# Altium schematic sheet 24V Multiphase Buck.SchDoc
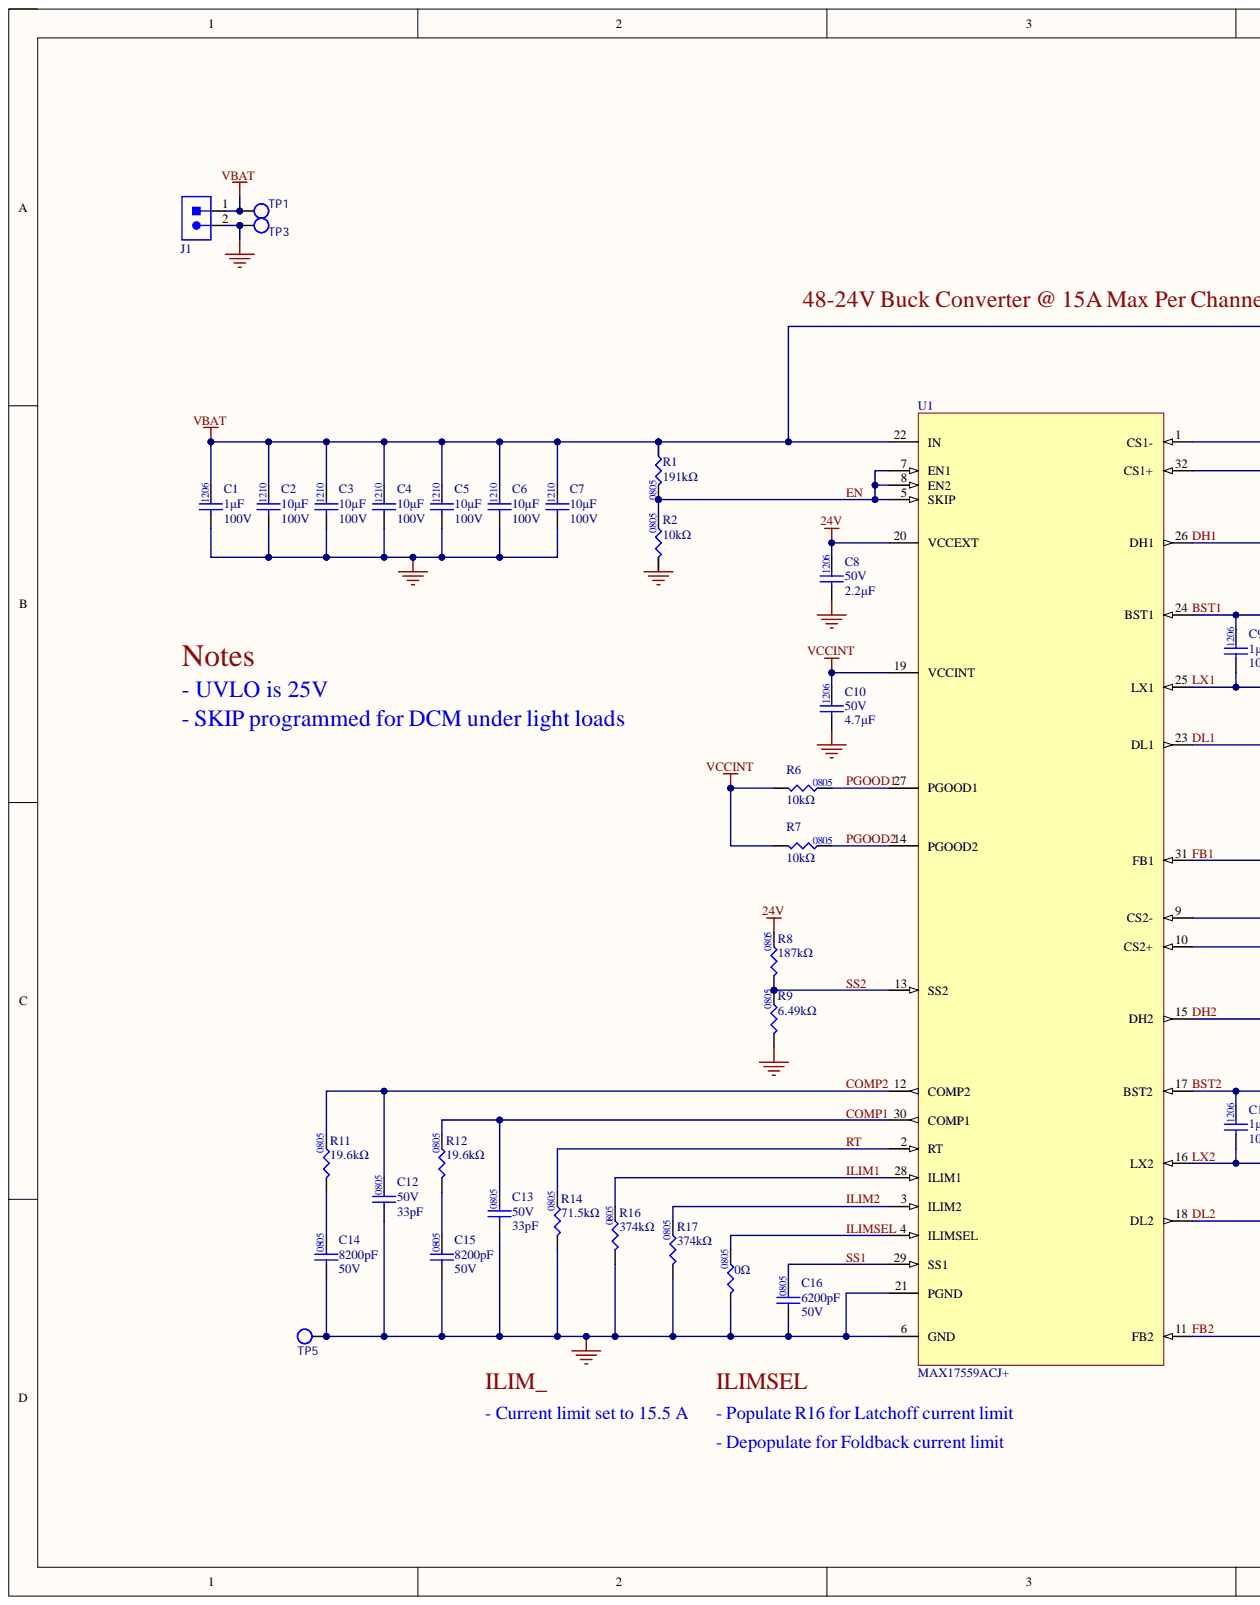
[diagram p0: 24V Multiphase Buck.SchDoc, left]
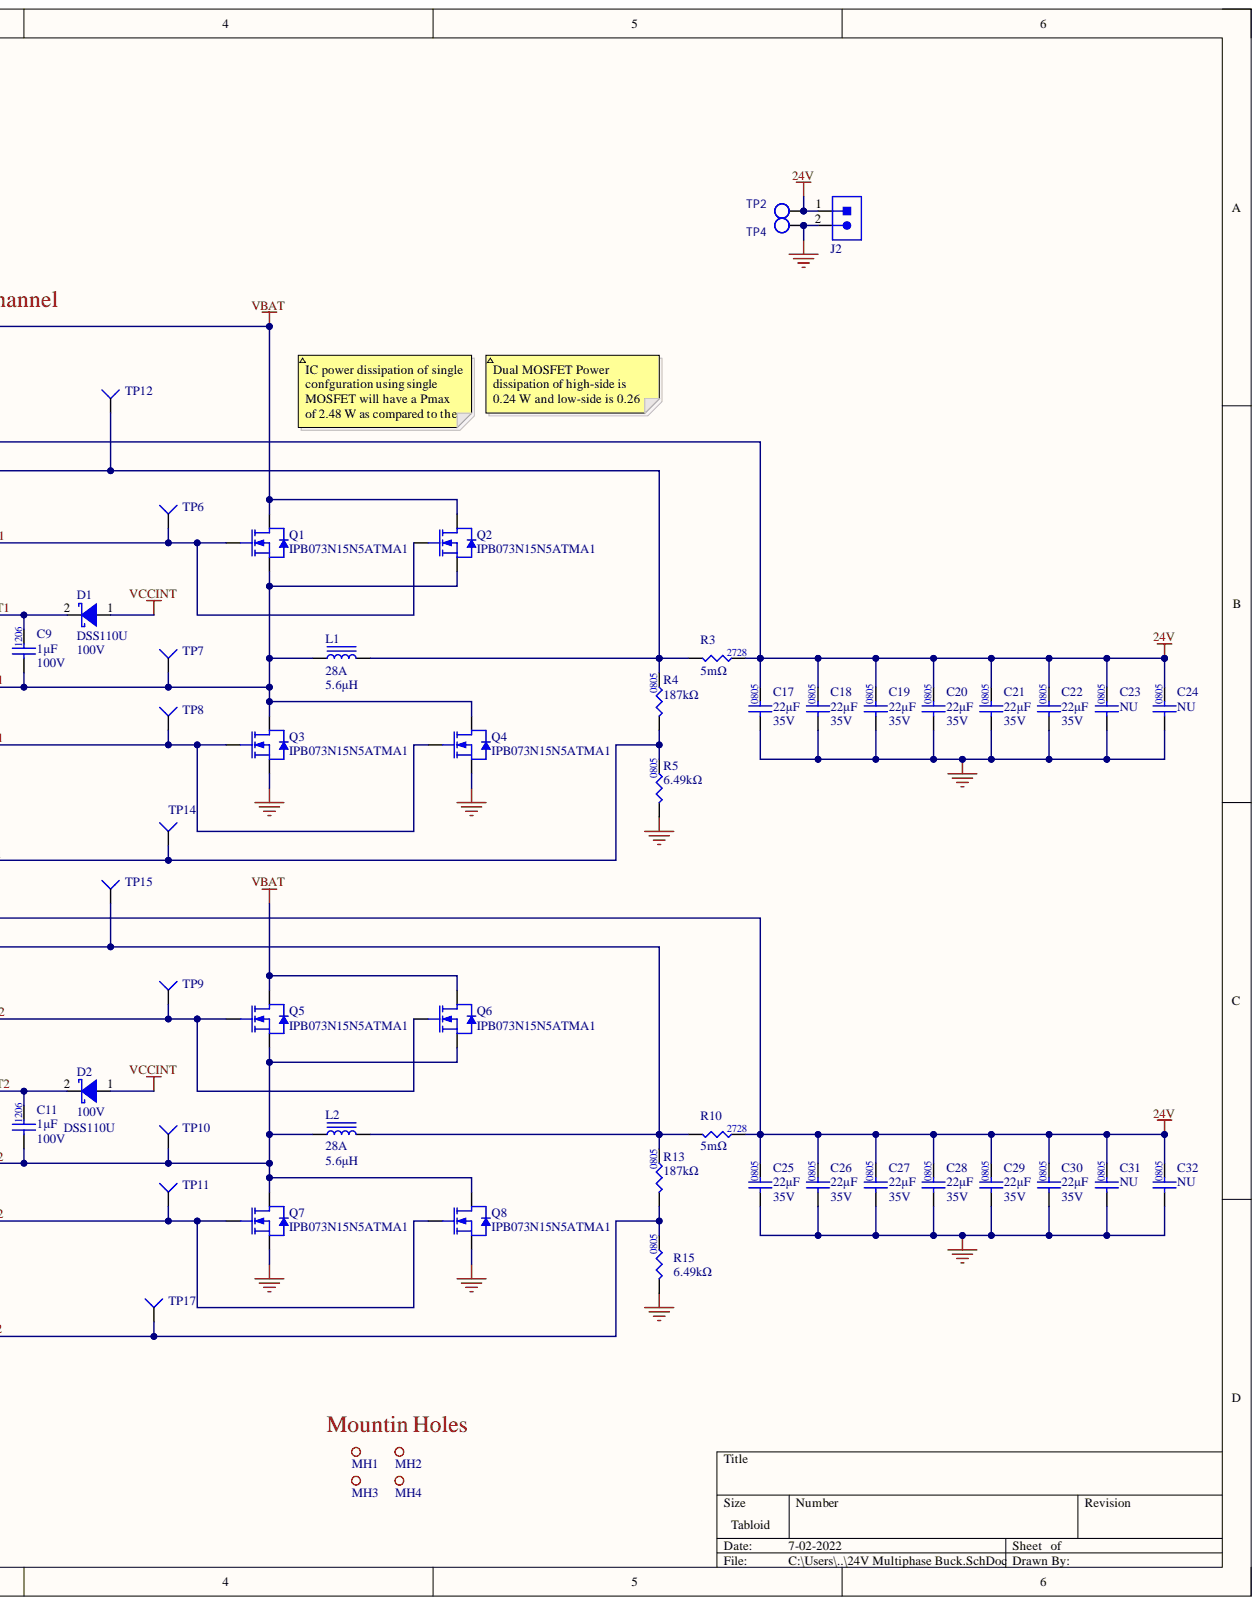
[diagram p1: 24V Multiphase Buck.SchDoc, right]

## Parts
C1  value 1μF  Package: 1206  Voltage Rating: 100V  [CAPC3216X190X50NL20T25]  (hidden comment: CAP_1uF_100V_1206)
C2  value 10μF  Package: 1210  Voltage Rating: 100V  [CAP1210]  (hidden comment: CAP_10uF_100V_1210)
C3  value 10μF  Package: 1210  Voltage Rating: 100V  [CAP1210]  (hidden comment: CAP_10uF_100V_1210)
C4  value 10μF  Package: 1210  Voltage Rating: 100V  [CAP1210]  (hidden comment: CAP_10uF_100V_1210)
C5  value 10μF  Package: 1210  Voltage Rating: 100V  [CAP1210]  (hidden comment: CAP_10uF_100V_1210)
C6  value 10μF  Package: 1210  Voltage Rating: 100V  [CAP1210]  (hidden comment: CAP_10uF_100V_1210)
C7  value 10μF  Package: 1210  Voltage Rating: 100V  [CAP1210]  (hidden comment: CAP_10uF_100V_1210)
C8  value 2.2μF  Voltage Rating: 50V  [CAP1206]  (hidden comment: CAP_2.2uF_50V_1206)
C9  value 1μF  Package: 1206  Voltage Rating: 100V  [CAPC3216X190X50NL20T25]  (hidden comment: CAP_1uF_100V_1206)
C10  value 4.7μF  Package: 1206  Voltage Rating: 50V  [CAP1206]  (hidden comment: CAP_4.7uF_50V_1206)
C11  value 1μF  Package: 1206  Voltage Rating: 100V  [CAPC3216X190X50NL20T25]  (hidden comment: CAP_1uF_100V_1206)
C12  value 33pF  Package: 0805  Voltage Rating: 50V  [CAP0805]  (hidden comment: CAP_33pF_50V_0805)
C13  value 33pF  Package: 0805  Voltage Rating: 50V  [CAP0805]  (hidden comment: CAP_33pF_50V_0805)
C14  Capacitance: 8200pF  Package: 0805  Voltage Rating: 50V  [CAP0805]  (hidden comment: CAP_8200pF_50V_0805)
C15  Capacitance: 8200pF  Package: 0805  Voltage Rating: 50V  [CAP0805]  (hidden comment: CAP_8200pF_50V_0805)
C16  Capacitance: 6200pF  Package/Footprint: 0805  Voltage Rating: 50V  [CAP0805]  (hidden comment: CAP_6200pF_50V_0805)
C17  value 22μF  Voltage Rating: 35V  [CAP1206]  (hidden comment: CAP_22uF_35V_1206)
C18  value 22μF  Voltage Rating: 35V  [CAP1206]  (hidden comment: CAP_22uF_35V_1206)
C19  value 22μF  Voltage Rating: 35V  [CAP1206]  (hidden comment: CAP_22uF_35V_1206)
C20  value 22μF  Voltage Rating: 35V  [CAP1206]  (hidden comment: CAP_22uF_35V_1206)
C21  value 22μF  Voltage Rating: 35V  [CAP1206]  (hidden comment: CAP_22uF_35V_1206)
C22  value 22μF  Voltage Rating: 35V  [CAP1206]  (hidden comment: CAP_22uF_35V_1206)
C23  value NU  [CAP1206]  (hidden comment: CAP_NU_1206)
C24  value NU  [CAP1206]  (hidden comment: CAP_NU_1206)
C25  value 22μF  Voltage Rating: 35V  [CAP1206]  (hidden comment: CAP_22uF_35V_1206)
C26  value 22μF  Voltage Rating: 35V  [CAP1206]  (hidden comment: CAP_22uF_35V_1206)
C27  value 22μF  Voltage Rating: 35V  [CAP1206]  (hidden comment: CAP_22uF_35V_1206)
C28  value 22μF  Voltage Rating: 35V  [CAP1206]  (hidden comment: CAP_22uF_35V_1206)
C29  value 22μF  Voltage Rating: 35V  [CAP1206]  (hidden comment: CAP_22uF_35V_1206)
C30  value 22μF  Voltage Rating: 35V  [CAP1206]  (hidden comment: CAP_22uF_35V_1206)
C31  value NU  [CAP1206]  (hidden comment: CAP_NU_1206)
C32  value NU  [CAP1206]  (hidden comment: CAP_NU_1206)
D1  Manufacturer Part Number 1: DSS110U  Vr (Max): 100V  [SOD-123FL]  (hidden comment: DIO_SCHOTTKY_100V_1A)
D2  Manufacturer Part Number 1: DSS110U  Vr (Max): 100V  [SOD-123FL]  (hidden comment: DIO_SCHOTTKY_100V_1A)
J1  CON_2POS_SCREW_TERM  [CON_2POS_SCREW_TERM_65A]  (value not drawn)
J2  CON_2POS_SCREW_TERM  [CON_2POS_SCREW_TERM_65A]  (value not drawn)
L1  Current Rating: 28A  Inductance: 5.6μH  [IND_VISHAY_IHLP6767GZ11]  (hidden comment: IND_5.6uH_28A)
L2  Current Rating: 28A  Inductance: 5.6μH  [IND_VISHAY_IHLP6767GZ11]  (hidden comment: IND_5.6uH_28A)
MH1  MOUNTING_HOLE_M3  [MOUNTING_HOLE_3mm]  (value not drawn)  (no BOM)
MH2  MOUNTING_HOLE_M3  [MOUNTING_HOLE_3mm]  (value not drawn)  (no BOM)
MH3  MOUNTING_HOLE_M3  [MOUNTING_HOLE_3mm]  (value not drawn)  (no BOM)
MH4  MOUNTING_HOLE_M3  [MOUNTING_HOLE_3mm]  (value not drawn)  (no BOM)
Q1  Manufacturer Part Number 1: IPB073N15N5ATMA1  [NMOS_DPAK263-3-2]  (hidden comment: NMOS_150V_114A)
Q2  Manufacturer Part Number 1: IPB073N15N5ATMA1  [NMOS_DPAK263-3-2]  (hidden comment: NMOS_150V_114A)
Q3  Manufacturer Part Number 1: IPB073N15N5ATMA1  [NMOS_DPAK263-3-2]  (hidden comment: NMOS_150V_114A)
Q4  Manufacturer Part Number 1: IPB073N15N5ATMA1  [NMOS_DPAK263-3-2]  (hidden comment: NMOS_150V_114A)
Q5  Manufacturer Part Number 1: IPB073N15N5ATMA1  [NMOS_DPAK263-3-2]  (hidden comment: NMOS_150V_114A)
Q6  Manufacturer Part Number 1: IPB073N15N5ATMA1  [NMOS_DPAK263-3-2]  (hidden comment: NMOS_150V_114A)
Q7  Manufacturer Part Number 1: IPB073N15N5ATMA1  [NMOS_DPAK263-3-2]  (hidden comment: NMOS_150V_114A)
Q8  Manufacturer Part Number 1: IPB073N15N5ATMA1  [NMOS_DPAK263-3-2]  (hidden comment: NMOS_150V_114A)
R1  value 191kΩ  Package/Footprint: 0805  [RES0805]  (hidden comment: RES_191kΩ_0805_1%)
R2  value 10kΩ  Package/Footprint: 0805  [RES0805]  (hidden comment: RES_10kΩ_0805_5%)
R3  value 5mΩ  Package/Footprint: 2728  [RES2728]  (hidden comment: RES_5mΩ_2728_1%)
R4  value 187kΩ  Package/Footprint: 0805  [RES0805]  (hidden comment: RES_187kΩ_0805_0.1%)
R5  value 6.49kΩ  Package/Footprint: 0805  [RES0805]  (hidden comment: RES_6.49kΩ_0805_1%)
R6  value 10kΩ  Package/Footprint: 0805  [RES0805]  (hidden comment: RES_10kΩ_0805_5%)
R7  value 10kΩ  Package/Footprint: 0805  [RES0805]  (hidden comment: RES_10kΩ_0805_5%)
R8  value 187kΩ  Package/Footprint: 0805  [RES0805]  (hidden comment: RES_187kΩ_0805_0.1%)
R9  value 6.49kΩ  Package/Footprint: 0805  [RES0805]  (hidden comment: RES_6.49kΩ_0805_1%)
R10  value 5mΩ  Package/Footprint: 2728  [RES2728]  (hidden comment: RES_5mΩ_2728_1%)
R11  value 19.6kΩ  Package/Footprint: 0805  [RES0805]  (hidden comment: RES_19.6kΩ_0805_1%)
R12  value 19.6kΩ  Package/Footprint: 0805  [RES0805]  (hidden comment: RES_19.6kΩ_0805_1%)
R13  value 187kΩ  Package/Footprint: 0805  [RES0805]  (hidden comment: RES_187kΩ_0805_0.1%)
R14  value 71.5kΩ  Package/Footprint: 0805  [RES0805]  (hidden comment: RES_71.5kΩ_0805_1%)
R15  value 6.49kΩ  Package/Footprint: 0805  [RES0805]  (hidden comment: RES_6.49kΩ_0805_1%)
R16  value 374kΩ  [RES1210]  (hidden comment: RES_374kΩ_1210_1%)
R17  value 374kΩ  [RES1210]  (hidden comment: RES_374kΩ_1210_1%)
R18  value 0Ω  Package/Footprint: 0805  [RES0805]  (hidden comment: RES_0Ω_0805)
TP1  TESTPOINT_LOOP  [TESTPOINT_LOOP]  (value not drawn)
TP2  TESTPOINT_LOOP  [TESTPOINT_LOOP]  (value not drawn)
TP3  TESTPOINT_LOOP  [TESTPOINT_LOOP]  (value not drawn)
TP4  TESTPOINT_LOOP  [TESTPOINT_LOOP]  (value not drawn)
TP5  TESTPOINT_LOOP  [TESTPOINT_LOOP]  (value not drawn)
TP6  TESTPOINT_1MM_CIRCLE  [TESTPOINT_CIRCLE_1MM]  (value not drawn)  (no BOM)
TP7  TESTPOINT_1MM_CIRCLE  [TESTPOINT_CIRCLE_1MM]  (value not drawn)  (no BOM)
TP8  TESTPOINT_1MM_CIRCLE  [TESTPOINT_CIRCLE_1MM]  (value not drawn)  (no BOM)
TP9  TESTPOINT_1MM_CIRCLE  [TESTPOINT_CIRCLE_1MM]  (value not drawn)  (no BOM)
TP10  TESTPOINT_1MM_CIRCLE  [TESTPOINT_CIRCLE_1MM]  (value not drawn)  (no BOM)
TP11  TESTPOINT_1MM_CIRCLE  [TESTPOINT_CIRCLE_1MM]  (value not drawn)  (no BOM)
TP12  TESTPOINT_1MM_CIRCLE  [TESTPOINT_CIRCLE_1MM]  (value not drawn)  (no BOM)
TP14  TESTPOINT_1MM_CIRCLE  [TESTPOINT_CIRCLE_1MM]  (value not drawn)  (no BOM)
TP15  TESTPOINT_1MM_CIRCLE  [TESTPOINT_CIRCLE_1MM]  (value not drawn)  (no BOM)
TP17  TESTPOINT_1MM_CIRCLE  [TESTPOINT_CIRCLE_1MM]  (value not drawn)  (no BOM)
U1  MAX17559ACJ+  [IC_32-TQFP]

## Nets
24V: C8.2 C17.2 C18.2 C19.2 C20.2 C21.2 C22.2 C23.2 C24.2 C25.2 C26.2 C27.2 C28.2 C29.2 C30.2 C31.2 C32.2 J2.1 R3.2 R8.2 R10.2 TP2.1 U1.1(CS1-) U1.9(CS2-) U1.20(VCCEXT)
GND: C1.1 C2.1 C3.1 C4.1 C5.1 C6.1 C7.1 C8.1 C10.1 C12.1 C13.1 C14.1 C15.1 C16.1 C17.1 C18.1 C19.1 C20.1 C21.1 C22.1 C23.1 C24.1 C25.1 C26.1 C27.1 C28.1 C29.1 C30.1 C31.1 C32.1 J1.2 J2.2 Q3.3 Q4.3 Q7.3 Q8.3 R5.1 R9.1 R14.1 R15.1 R16.1 R17.1 R18.1 TP3.1 TP4.1 TP5.1 U1.6(GND) U1.21(PGND)
VBAT: C1.2 C2.2 C3.2 C4.2 C5.2 C6.2 C7.2 J1.1 Q1.2 Q2.2 Q5.2 Q6.2 R1.2 TP1.1 U1.22(IN)
VCCINT: C10.2 D1.1 D2.1 R6.1 R7.1 U1.19(VCCINT)
BST1: C9.2 D1.2 U1.24(BST1)
BST2: C11.2 D2.2 U1.17(BST2)
COMP1: C13.2 R12.2 U1.30(COMP1)
COMP2: C12.2 R11.2 U1.12(COMP2)
DH1: Q1.1 Q2.1 TP6.1 U1.26(DH1)
DH2: Q5.1 Q6.1 TP9.1 U1.15(DH2)
DL1: Q3.1 Q4.1 TP8.1 U1.23(DL1)
DL2: Q7.1 Q8.1 TP11.1 U1.18(DL2)
EN: R1.1 R2.2 U1.5(SKIP) U1.7(EN1) U1.8(EN2)
FB1: R4.1 R5.2 TP14.1 U1.31(FB1)
FB2: R13.1 R15.2 TP17.1 U1.11(FB2)
ILIM1: R16.2 U1.28(ILIM1)
ILIM2: R17.2 U1.3(ILIM2)
ILIMSEL: R18.2 U1.4(ILIMSEL)
LX1: C9.1 L1.1 Q1.3 Q2.3 Q3.2 Q4.2 TP7.1 U1.25(LX1)
LX2: C11.1 L2.1 Q5.3 Q6.3 Q7.2 Q8.2 TP10.1 U1.16(LX2)
PGOOD1: R6.2 U1.27(PGOOD1)
PGOOD2: R7.2 U1.14(PGOOD2)
RT: R14.2 U1.2(RT)
SS1: C16.2 U1.29(SS1)
SS2: R8.1 R9.2 U1.13(SS2)
NetC14_2: C14.2 R11.1
NetC15_2: C15.2 R12.1
NetL1_2: L1.2 R3.1 R4.2 TP12.1 U1.32(CS1+)
NetL2_2: L2.2 R10.1 R13.2 TP15.1 U1.10(CS2+)

Not connected: R2 1

## Library data of the parts
C1 C9 C11 = CAP_1uF_100V_1206: CAP CER 1UF 100V X7R 1206; Component Kind: Standard; Mounting Type: SMD; Supplier 1: Digi-Key; Supplier Part Number 1: 490-16468-1-ND; Library Name: UWRT_Schematic_Library_2020.SchLib
C2-C7 = CAP_10uF_100V_1210: CAP CER 10uF 100V X7S 10% 1210; Manufacturer 1: Murata; Manufacturer Part Number 1: GRM32EC72A106KE05L; Component Kind: Standard; Dielectric: X7S; Mounting Type: SMD; Supplier 1: Digi-Key; Supplier Part Number 1: 490-16266-1-ND; Library Name: UWRT_Schematic_Library_2020.SchLib
C8 = CAP_2.2uF_50V_1206: CAP CER 2.2UF 50V X7R 1206; Manufacturer 1: Murata Electronics; Manufacturer Part Number 1: GCM31CR71H225KA55L; Component Kind: Standard; Dielectric: X7R; Supplier 1: Digi-Key; Supplier Part Number 1: 490-4796-1-ND; Library Name: UWRT_Schematic_Library_2020.SchLib
C10 = CAP_4.7uF_50V_1206: CAP CER 4.7UF 50V X7R 1206; Manufacturer 1: Murata; Manufacturer Part Number 1: GRM31CR71H475KA12L; Component Kind: Standard; Dielectric: X7R; Mounting Type: SMD; Supplier 1: Digi-Key; Supplier Part Number 1: 490-6521-1-ND; Library Name: UWRT_Schematic_Library_2020.SchLib
C12 C13 = CAP_33pF_50V_0805: CAP CER 33PF 50V C0G/NP0 0805; Manufacturer 1: Samsung Electro-Mechanics; Manufacturer Part Number 1: CL21C330JBANNNC; Component Kind: Standard; Dielectric: C0G/NP0; Mounting Type: SMD; Supplier 1: Digi-Key; Supplier Part Number 1: 1276-1105-1-ND; Library Name: UWRT_Schematic_Library_2020.SchLib
C14 C15 = CAP_8200pF_50V_0805: CAP CER 8200PF 50V X7R 0805; Manufacturer: YAGEO; Manufacturer Part Number: CC0805KRX7R9BB822; Component Kind: Standard; Dielectric: X7R; Mounting Type: SMD; Supplier 1: Digi-Key; Supplier Part Number 1: 311-4331-1-ND; Library Name: UWRT_Schematic_Library_2020.SchLib
C16 = CAP_6200pF_50V_0805: CAP CER 6200PF 50V C0G/NP0 0805; Manufacturer 1: Murata Electronics; Manufacturer Part Number 1: GRM2195C1H622JA01D; Component Kind: Standard; Supplier 1: Digi-Key; Supplier Part Number 1: 490-1637-1-ND; Library Name: UWRT_Schematic_Library_2020.SchLib
C17-C22 C25-C30 = CAP_22uF_35V_1206: CAP CER 22UF 35V X5R 1206; Manufacturer 1: TDK Corporation; Manufacturer Part Number 1: C3216X5R1V226M160AC; Package/Footprint: 1205; Component Kind: Standard; Dielectric: X5R; Mounting Type: Surface Mount; Supplier 1: Digi-Key; Supplier Part Number 1: 445-8045-1-ND; Library Name: UWRT_Schematic_Library_2020.SchLib
C23 C24 C31 C32 = CAP_NU_1206: PLACEHOLDER CAPACITOR; Component Kind: Standard; Mounting Type: Surface Mount; Package: 1206; Library Name: UWRT_Schematic_Library_2020.SchLib
D1 D2 = DIO_SCHOTTKY_100V_1A: DIODE SCHOTTKY 100V 1A SOD-123F; Manufacturer 1: SMC Diode Solutions; Component Kind: Standard; Mounting Type: SMD; Package/Footprint: SOD-123F; Supplier 1: Digi-Key; Supplier Part Number 1: 1655-DSS110UCT-ND; Library Name: UWRT_Schematic_Library_2020.SchLib
J1 J2 = CON_2POS_SCREW_TERM_65A: PCB TERMINAL BLOCKS RISING CLAMP; Manufacturer 1: Amphenol Anytek; Manufacturer Part Number 1: VP0265840000G; Component Kind: Standard; Mounting Type: TH; Package/Footprint: TERMINAL; Supplier 1: Digi-Key; Supplier Part Number 1: 1818-VP0265840000G-ND; Library Name: UWRT_Schematic_Library_2020.SchLib
L1 L2 = IND_5.6uH_28A: FIXED IND 5.6UH 28A 4.44MOHM SMD; Manufacturer 1: Vishay Dale; Manufacturer Part Number 1: IHLP6767GZER5R6M11; Inductance Tolerance: 20%; Saturation Current: 21A; Component Kind: Standard; DC Resistance (DCR): 4.44mOhm; Mounting Type: SMD; Supplier 1: Digi-Key; Supplier Part Number 1: 541-1283-1-ND; Library Name: UWRT_Schematic_Library_2020.SchLib
Q1-Q8 = NMOS_150V_114A: MOSFET N-CH 150V 114A TO263-3; Manufacturer 1: Infineon Technologies; Package/Footprint: TO-263-3, D²Pak; Vgs (Max): ±20V; Component Kind: Standard; Id: 114A; Mounting Type: SMD; Supplier 1: Digi-Key; Supplier Part Number 1: IPB073N15N5ATMA1CT-ND; Vdss: 150V; Library Name: UWRT_Schematic_Library_2020.SchLib
R1 = RES_191kΩ_0805_1%: RES 191K OHM 1% 1/8W 0805; Manufacturer 1: Yageo; Manufacturer Part Number 1: RC0805FR-07191KL; Component Kind: Standard; Mounting Type: SMD; Supplier 1: Digi-Key; Supplier Part Number 1: 311-191KCRTR-ND; Library Name: UWRT_Schematic_Library_2020.SchLib
R2 R6 R7 = RES_10kΩ_0805_5%: RES 10K OHM 5% 1/8W 0805; Manufacturer 1: Panasonic Electronic Components; Manufacturer Part Number 1: ERJ-6GEYJ103V; Component Kind: Standard; LCSC Part #: C17414; Mounting Type: SMD; Supplier 1: Digi-Key; Supplier Part Number 1: P10KACT-ND; Library Name: UWRT_Schematic_Library_2020.SchLib
R3 R10 = RES_5mΩ_2728_1%: RES 0.005 OHM 1% 4W 2827; Manufacturer 1: Stackpole Electronics Inc; Manufacturer Part Number 1: CSSH2728FT5L00; Component Kind: Standard; Mounting Type: SMD; Supplier 1: Digi-Key; Supplier Part Number 1: CSSH2728FT5L00CT-ND; Library Name: UWRT_Schematic_Library_2020.SchLib
R4 R8 R13 = RES_187kΩ_0805_0.1%: RES 187K OHM 0.1% 1/8W 0805; Manufacturer 1: Yageo; Manufacturer Part Number 1: RT0805BRD07187KL; Component Kind: Standard; Mounting Type: SMD; Supplier 1: Digi-Key; Supplier Part Number 1: 13-RT0805BRD07187KLCT-ND; Library Name: UWRT_Schematic_Library_2020.SchLib
R5 R9 R15 = RES_6.49kΩ_0805_1%: RES 6.49K OHM 1% 1/8W 0805; Manufacturer 1: Yageo; Manufacturer Part Number 1: RC0805FR-076K49L; Component Kind: Standard; Mounting Type: SMD; Supplier 1: Digi-Key; Supplier Part Number 1: 311-6.49KCRCT-ND; Library Name: UWRT_Schematic_Library_2020.SchLib
R11 R12 = RES_19.6kΩ_0805_1%: RES SMD 19.6K OHM 1% 1/8W 0805; Manufacturer 1: Yageo; Manufacturer Part Number 1: AC0805FR-0719K6L; Component Kind: Standard; Mounting Type: SMD; Supplier 1: Digi-Key; Supplier Part Number 1: YAG5355CT-ND; Library Name: UWRT_Schematic_Library_2020.SchLib
R14 = RES_71.5kΩ_0805_1%: RES 71.5K OHM 1% 1/8W 0805; Manufacturer 1: Yageo; Manufacturer Part Number 1: RC0805FR-0771K5L; Component Kind: Standard; Mounting Type: SMD; Supplier 1: Digi-Key; Supplier Part Number 1: 311-71.5KCRCT-ND; Library Name: UWRT_Schematic_Library_2020.SchLib
R16 R17 = RES_374kΩ_1210_1%: RES 374K OHM 1% 1/3W 1210; Manufacturer 1: Stackpole Electronics Inc; Manufacturer Part Number 1: RMCF1210FT374K; Component Kind: Standard; Supplier 1: Digi-Key; Supplier Part Number 1: 738-RMCF1210FT374KCT-ND; Library Name: UWRT_Schematic_Library_2020.SchLib
R18 = RES_0Ω_0805: RES 0 OHM JUMPER 1/8W 0805; Manufacturer 1: YAGEO; Manufacturer Part Number 1: RC0805JR-070RL; Component Kind: Standard; Mounting Type: SMD; Supplier 1: Digi-Key; Supplier Part Number 1: 311-0.0ARCT-ND; Library Name: UWRT_Schematic_Library_2020.SchLib
TP1-TP5 = TESTPOINT_LOOP: TESTPOINT THRUHOLE; Manufacturer 1: Keystone Electronics; Manufacturer Part Number 1: 5002; Package/Footprint: TH; Component Kind: Standard; Mounting Type: TH; Supplier 1: Digi-Key; Supplier Part Number 1: 36-5002-ND; Library Name: UWRT_Schematic_Library_2020.SchLib
TP6-TP12 TP14 TP15 TP17 = TESTPOINT_1MM_CIRCLE: TESTPOINT 1MM CIRCLE; Library Name: UWRT_Schematic_Library_2020.SchLib
U1 = MAX17559ACJ+: IC REG CTRLR BUCK 32TQFP; Manufacturer 1: Maxim; Package/Footprint: 32-TQFP; Component Kind: Standard; Manufacturer Part Number: MAX17559ACJ+; Mounting Type: SMD; Supplier 1: Digi-Key; Supplier Part Number 1: MAX17559ACJ+-ND; Library Name: UWRT_Schematic_Library_2020.SchLib
MH1-MH4 = MOUNTING_HOLE_M3: Description: MOUNTING_HOLE_M3; Library Name: UWRT_Schematic_Library_2020.SchLib
